# Altium schematic sheet MAX.SchDoc
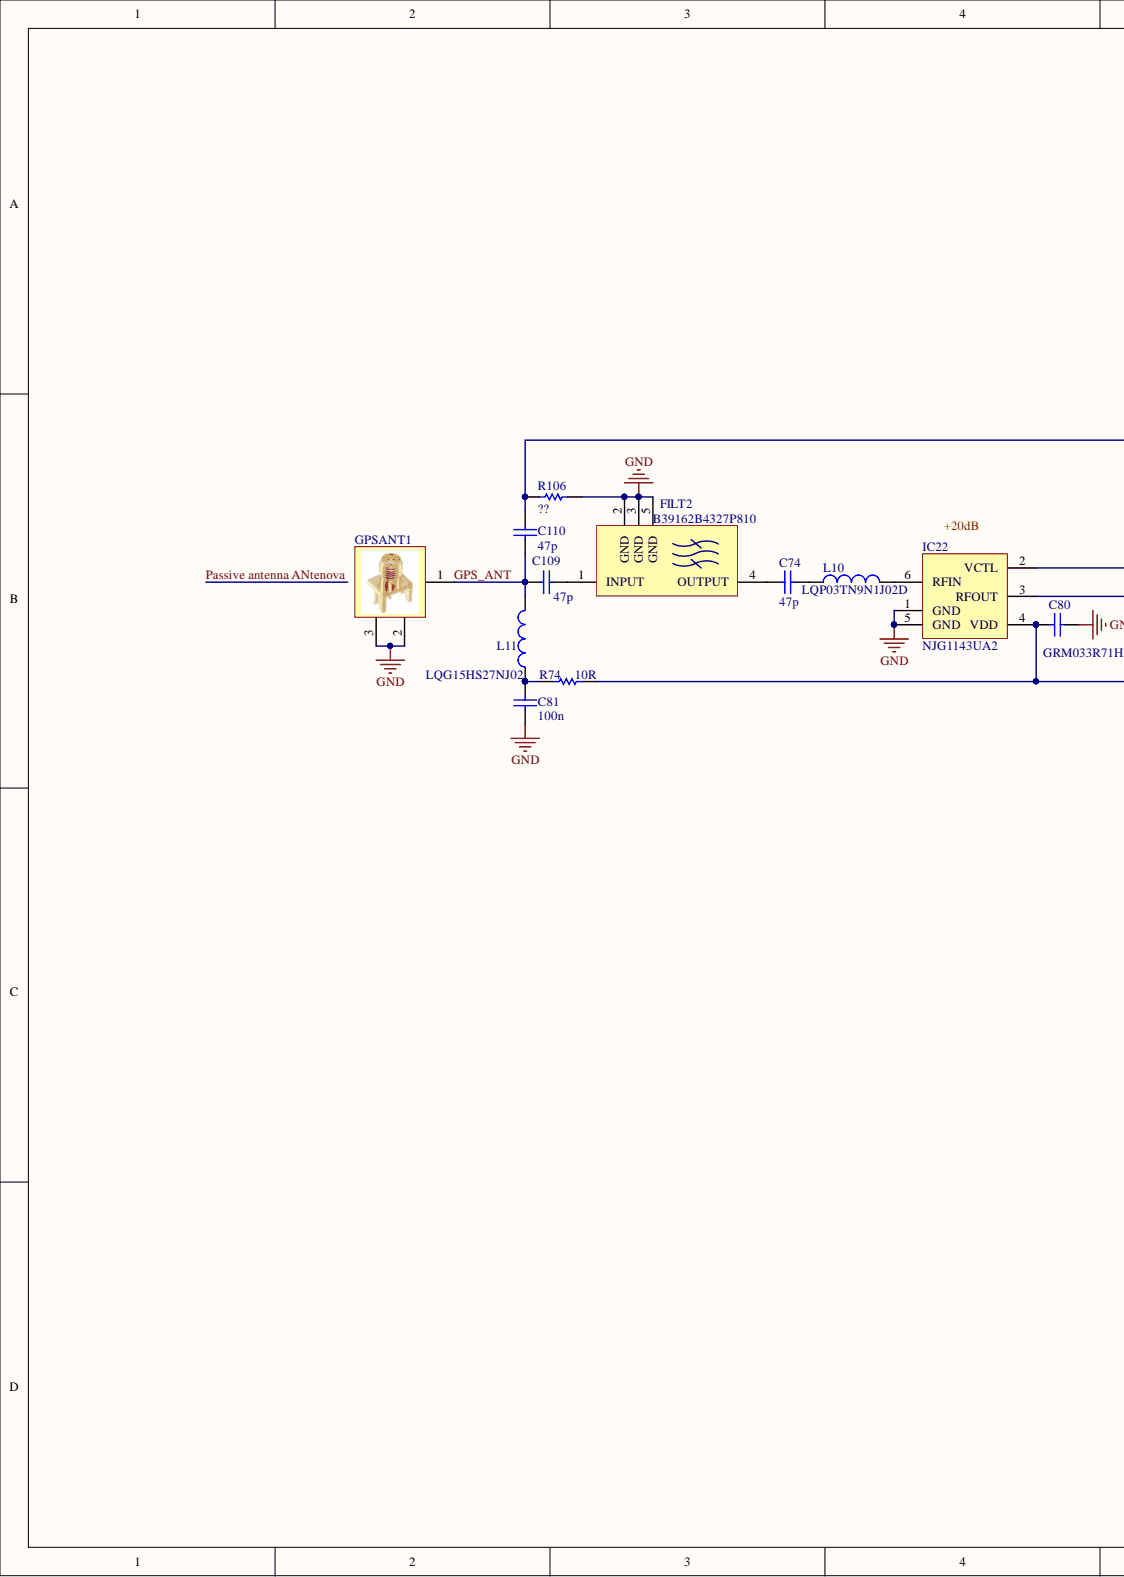
[diagram p0: MAX.SchDoc, left]
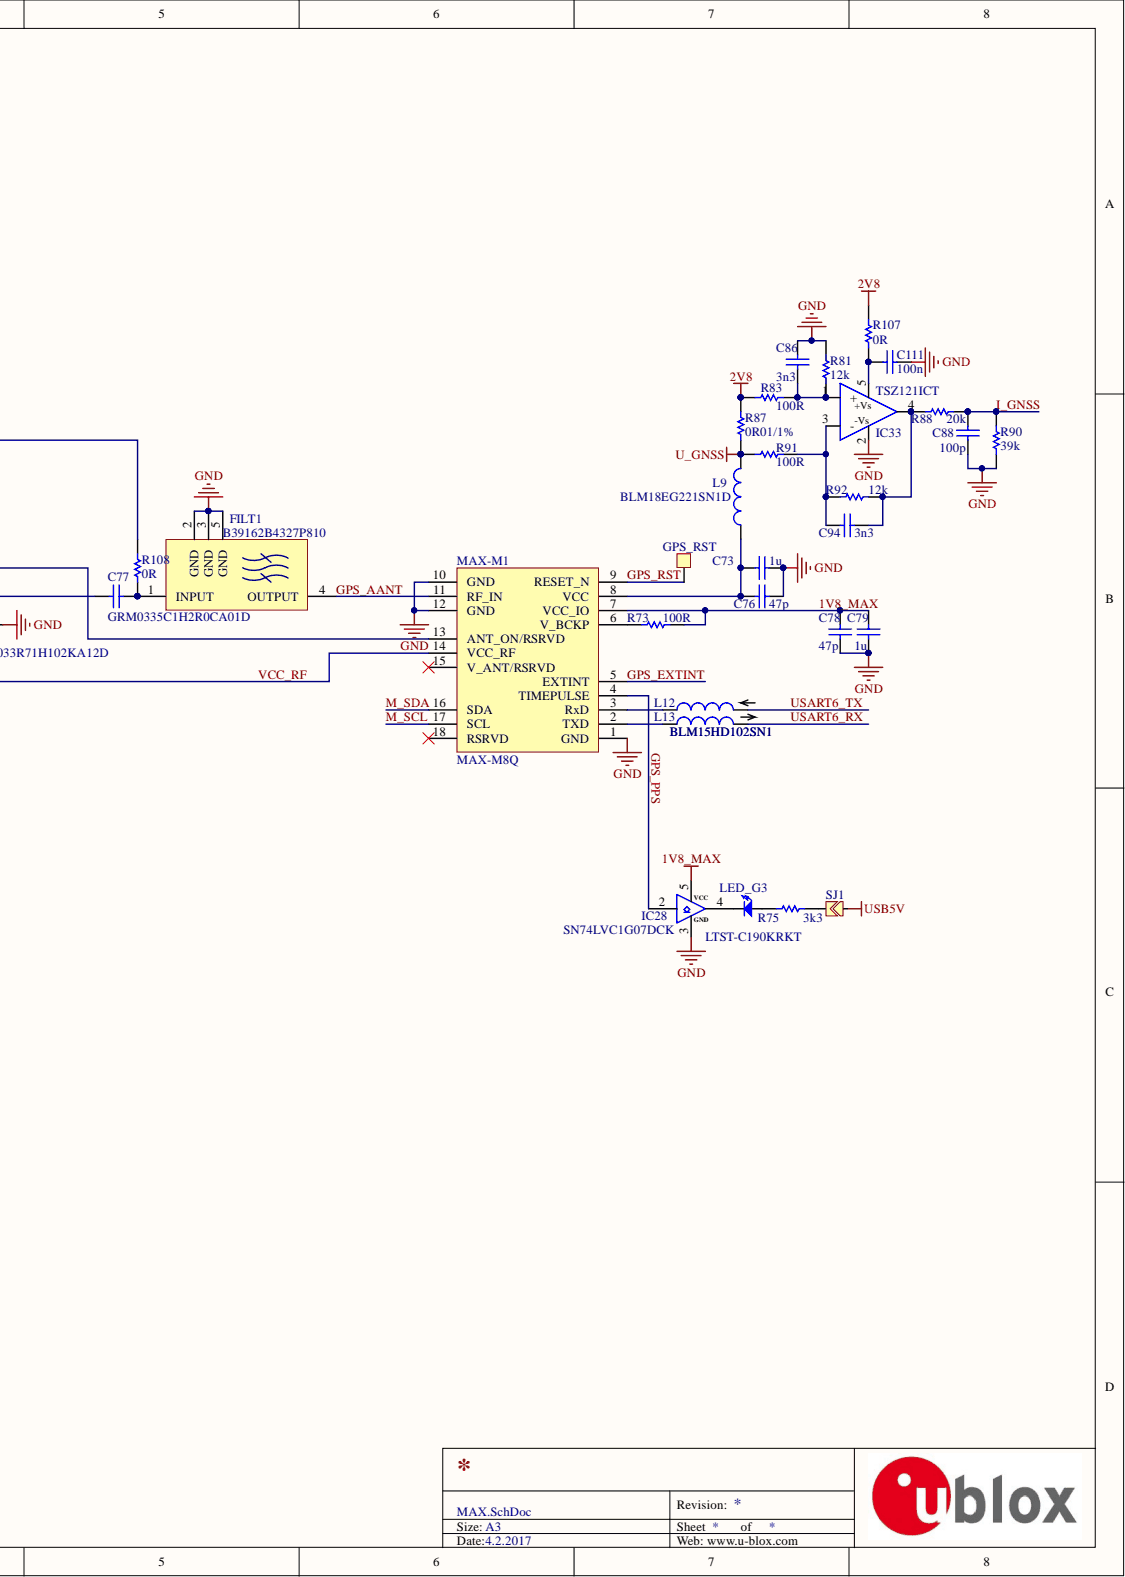
[diagram p1: MAX.SchDoc, right]

## Parts
C73  1u  [C0603]
C74  47p  [C0201]
C76  47p  [C0402]
C77  GRM0335C1H2R0CA01D  [C0201]
C78  47p  [C0402]
C79  1u  [C0603]
C80  GRM033R71H102KA12D  [C0201]
C81  100n  [C0402]
C86  3n3  [C0402]
C88  100p  [C0402]
C94  3n3  [C0402]
C109  47p  [C0201]
C110  47p  [C0201]
C111  100n  [C0402]
FILT1  B39162B4327P810  [B4327]
FILT2  B39162B4327P810  [B4327]
GPS_RST  Header 1  [HEADER1 (1x1) SMT]  (value not drawn)  (no BOM)
GPSANT1  Molex 0732511150  [Molex 0732511150]  (value not drawn)
IC22  NJG1143UA2  [EPFFP6-A2]
IC28  SN74LVC1G07DCK  [DCK]
IC33  TSZ121ICT  [SC70_(DCK)]
L9  BLM18EG221SN1D  [L0603]
L10  LQP03TN9N1J02D  [L0201]
L11  LQG15HS27NJ02  [L0402]
L12  BLM15HD102SN1  [L0402]
L13  BLM15HD102SN1  [L0402]
LED_G3  LTST-C190KRKT  [LED0603 - RED]
MAX-M1  MAX-M8Q  [MAX-7Q]
R73  100R  [R0402]
R74  10R  [R0402]
R75  3k3  [R0402]
R81  12k  [R0402]
R83  100R  [R0402]
R87  0R01/1%  [R0603]
R88  20k  [R0402]
R90  39k  [R0402]
R91  100R  [R0402]
R92  12k  [R0402]
R106  ??  [R0402]
R107  0R  [R0402]
R108  0R  [R0402]
SJ1  Pacman1  [Pacman1]  (value not drawn)  (no BOM)

## Nets
2V8: R83.1 R87.2 R107.2
GND: C73.1 C76.1 C78.1 C79.1 C80.2 C81.2 C86.2 C88.1 C111.1 FILT1.2(GND) FILT1.3(GND) FILT1.5(GND) FILT2.2(GND) FILT2.3(GND) FILT2.5(GND) GPSANT1.2 GPSANT1.3 IC22.1(GND) IC22.5(GND) IC28.3 IC33.2 MAX-M1.1(GND) MAX-M1.10(GND) MAX-M1.12(GND) R81.2 R90.1 R106.1
U_GNSS: L9.2 R87.1 R91.1
USB5V: SJ1.2
1V8_MAX: C78.2 C79.2 IC28.5 MAX-M1.7(VCC_IO) R73.1
GPS_AANT: FILT1.4(OUTPUT) MAX-M1.11(RF_IN)
GPS_ANT: C109.2 C110.2 GPSANT1.1 L11.2
GPS_EXTINT: MAX-M1.5(EXTINT)
GPS_PPS: IC28.2 MAX-M1.4(TIMEPULSE)
GPS_RST: GPS_RST.1 MAX-M1.9(RESET_N)
I_GNSS: C88.2 R88.1 R90.2
M_SCL: MAX-M1.17(SCL)
M_SDA: MAX-M1.16(SDA)
USART6_RX: L13.2
USART6_TX: L12.2
VCC_RF: C80.1 IC22.4(VDD) MAX-M1.14(VCC_RF) R74.1
NetC73_2: C73.2 C76.2 L9.1 MAX-M1.8(VCC)
NetC74_1: C74.1 L10.1
NetC74_2: C74.2 FILT2.4(OUTPUT)
NetC77_1: C77.1 IC22.3(RFOUT)
NetC77_2: C77.2 FILT1.1(INPUT) R108.1
NetC81_1: C81.1 L11.1 R74.2
NetC86_1: C86.1 IC33.1(+) R81.1 R83.2
NetC94_1: C94.1 IC33.4 R88.2 R92.1
NetC94_2: C94.2 IC33.3(-) R91.2 R92.2
NetC109_1: C109.1 FILT2.1(INPUT)
NetC110_1: C110.1 R106.2 R108.2
NetC111_2: C111.2 IC33.5 R107.1
NetIC22_2: IC22.2(VCTL) MAX-M1.13(ANT_ON/RSRVD)
NetIC22_6: IC22.6(RFIN) L10.2
NetIC28_4: IC28.4 LED_G3.K
NetL12_1: L12.1 MAX-M1.3(RxD)
NetL13_1: L13.1 MAX-M1.2(TXD)
NetLED_G3_A: LED_G3.A R75.1
NetMAX-M1_6: MAX-M1.6(V_BCKP) R73.2
NetR75_2: R75.2 SJ1.1
PASSIVE ANTENNA ANTENOVA:

Not connected: MAX-M1 15(V_ANT/RSRVD) 18(RSRVD)

## Library data of the parts
C73 C74 C76-C81 C86 C88 C94 C109-C111 = Capacitor SMD: SMD chip capacitor; Value: Xn; Component Kind: Standard; LatestRevisionDate: 26-Dec-2008; Published: 26-Dec-2008; Signal Integrity: Cap; Simulation: CAP; Library Name: BorisPassive.SchLib; Publisher: www.poscope.com
FILT1 FILT2 = B39162B4327P810: B4327 SAW RF Filter GPS + COMPASS + GLONASS; Component Kind: Standard; Library Name: BorisPassive.SchLib
GPS_RST = Header 1: Header 1 pin, 2,54mm raster; Library Name: BorisHeaders.SchLib
GPSANT1 = Molex 0732511150: 50 Ohms, SMA Jack Receptacle, Edge Mount; Component Kind: Standard; Library Name: BorisCon.SchLib
IC22 = NJG1143UA2: GPS LOW NOISE AMPLIFIER GaAs MMIC; Component Kind: Standard; Library Name: BorisIC1.SchLib
IC28 = SN74LVC1G07DCK: Single buffer/driver with open-drain output.; Component Kind: Standard; datasheet: http://www.ti.com/lit/ds/symlink/sn74lvc1g07.pdf; link: http://www.ti.com/product/sn74lvc1g07; Library Name: BorisIC1.SchLib
IC33 = TSZ121ICT: Very high accuracy (5 µV) zero drift micropower 5 V operational   amplifiers; Component Kind: Standard; Library Name: BorisIC1.SchLib
L9-L13 = Inductor SMD: Value: XuH; Component Kind: Standard; LatestRevisionDate: 21 March 2009; Published: 21 March 2009; Library Name: BorisPassive.SchLib; Publisher: www.poscope.com
LED_G3 = LEDmini: Component Kind: Standard; Description: LEDmini; Library Name: BorisSemi.SchLib
MAX-M1 = MAX-7Q: u-blox 7 GPS/GNSS modules; Component Kind: Standard; Library Name: uBlox.SchLib
R73-R75 R81 R83 R87 R88 R90-R92 R106-R108 = Resistor SMD: SMD chip resistor; Value: Xk; Component Kind: Standard; LatestRevisionDate: 26-Dec-2008; Published: 26-Dec-2008; Signal Integrity: Res Semi; Simulation: RES; Library Name: BorisPassive.SchLib; Publisher: www.poscope.com
SJ1 = Pacman1: Solder jumper 2 pins; Library Name: BorisMech.SchLib
